# Altium schematic sheet Boost_Bar.SchDoc
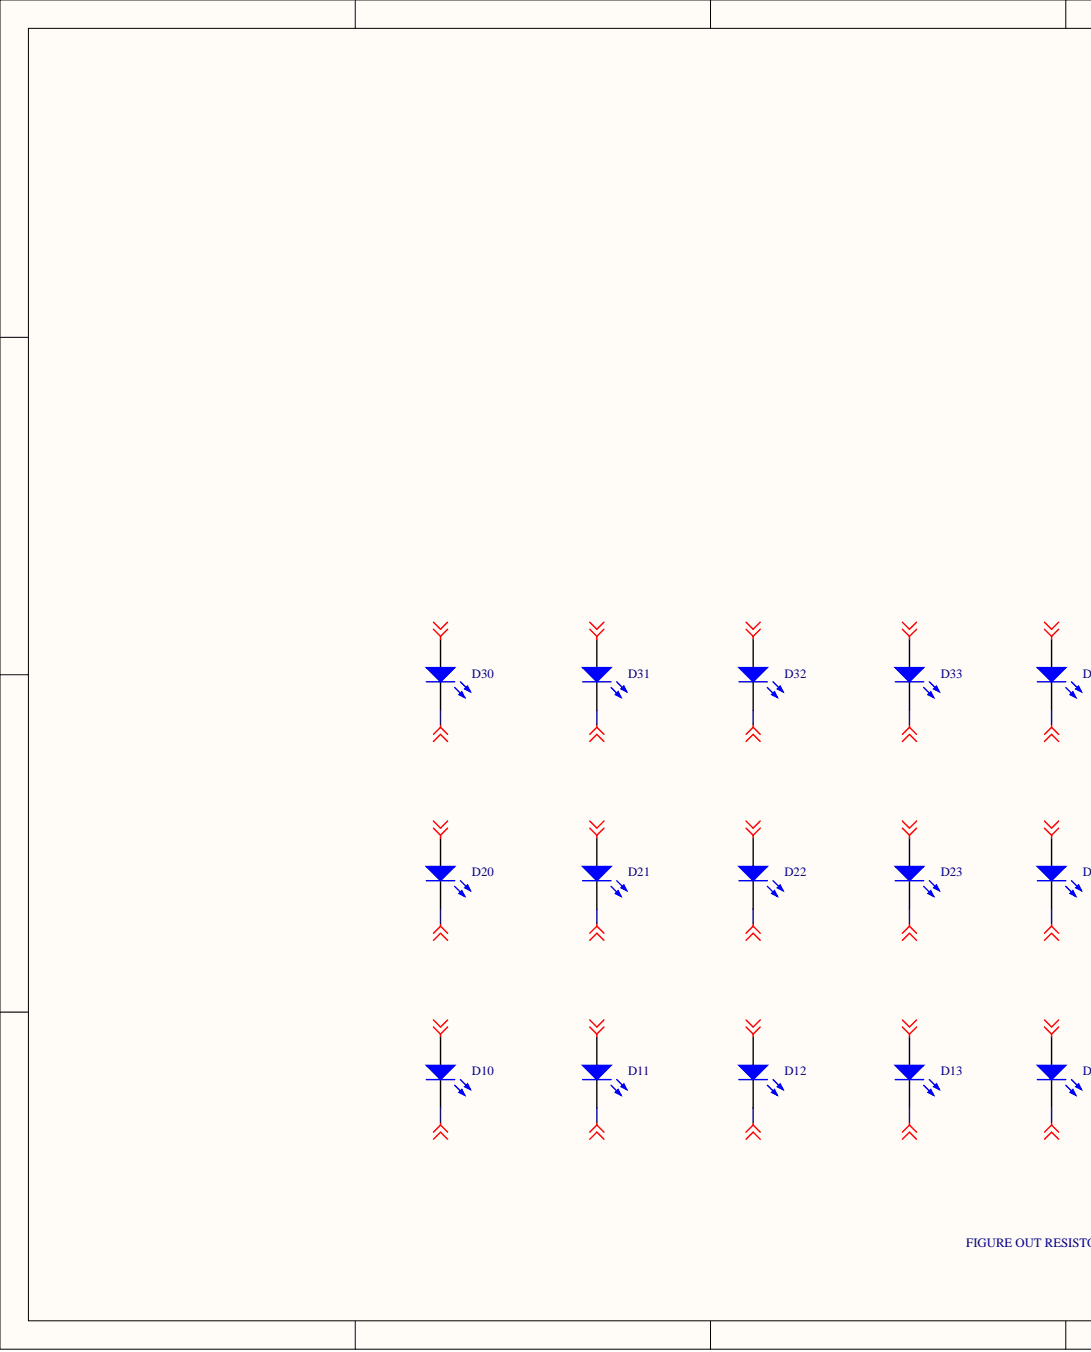
[diagram p0: Boost_Bar.SchDoc, left]
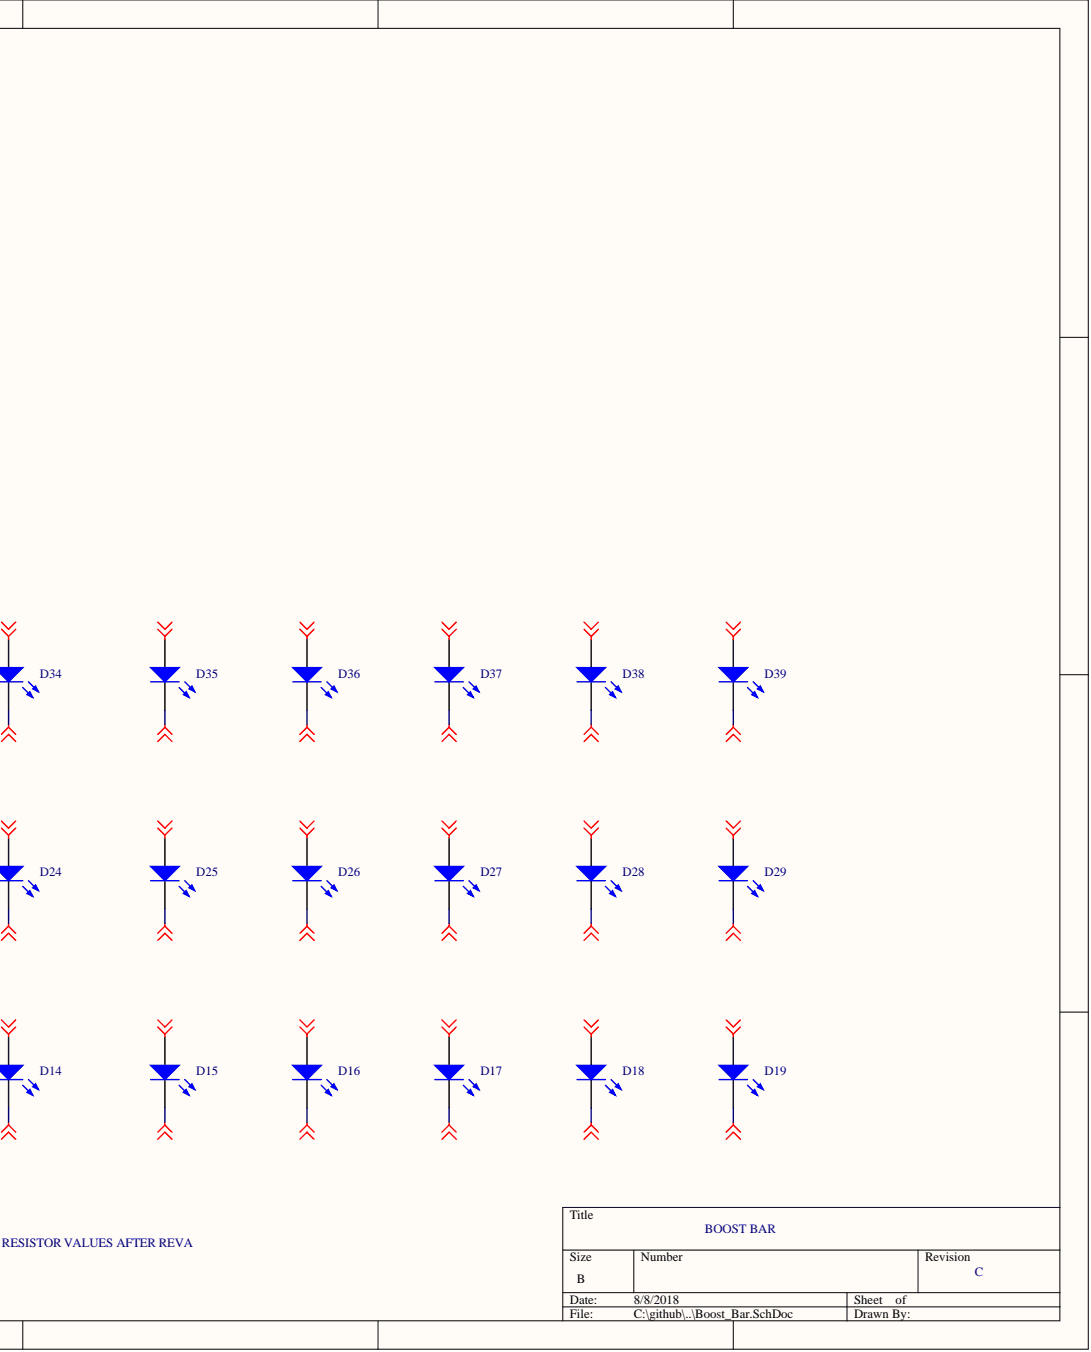
[diagram p1: Boost_Bar.SchDoc, right]

## Parts
D10  (no value)  [DIODE_0603]
D11  (no value)  [DIODE_0603]
D12  (no value)  [DIODE_0603]
D13  (no value)  [DIODE_0603]
D14  (no value)  [DIODE_0603]
D15  (no value)  [DIODE_0603]
D16  (no value)  [DIODE_0603]
D17  (no value)  [DIODE_0603]
D18  (no value)  [DIODE_0603]
D19  (no value)  [DIODE_0603]
D20  (no value)  [DIODE_0603]
D21  (no value)  [DIODE_0603]
D22  (no value)  [DIODE_0603]
D23  (no value)  [DIODE_0603]
D24  (no value)  [DIODE_0603]
D25  (no value)  [DIODE_0603]
D26  (no value)  [DIODE_0603]
D27  (no value)  [DIODE_0603]
D28  (no value)  [DIODE_0603]
D29  (no value)  [DIODE_0603]
D30  (no value)  [DIODE_0603]
D31  (no value)  [DIODE_0603]
D32  (no value)  [DIODE_0603]
D33  (no value)  [DIODE_0603]
D34  (no value)  [DIODE_0603]
D35  (no value)  [DIODE_0603]
D36  (no value)  [DIODE_0603]
D37  (no value)  [DIODE_0603]
D38  (no value)  [DIODE_0603]
D39  (no value)  [DIODE_0603]

## Nets
D0: D10.1 D11.1 D12.1 D13.1 D14.1 D15.1 D16.1 D17.1 D18.1 D19.1
D1: D20.1 D21.1 D22.1 D23.1 D24.1 D25.1 D26.1 D27.1 D28.1 D29.1
D2: D30.1 D31.1 D32.1 D33.1 D34.1 D35.1 D36.1 D37.1 D38.1 D39.1
G0/IO_VB3N2_69: D10.2 D20.2 D30.2
G1/IO_VB3N2_70: D11.2 D21.2 D31.2
G2/IO_VB3N1_78: D12.2 D22.2 D32.2
G3/IO_VB5N0_143: D13.2 D23.2 D33.2
G4/IO_VB3N1_81: D14.2 D24.2 D34.2
G5/IO_VB3N1_82: D15.2 D25.2 D35.2
G6/IO_VB3N1_83: D16.2 D26.2 D36.2
G7/IO_VB3N0_87: D17.2 D27.2 D37.2
G8/IO_VB6N3_166: D18.2 D28.2 D38.2
G9/IO_VB4N3_93: D19.2 D29.2 D39.2

## Library data of the parts
D10-D39 = LED0: Typical INFRARED GaAs LED; Component Kind: Standard; LatestRevisionDate: 08-Jul-2005; LatestRevisionNote: Mech Layer 1 removed.; Library Name: Miscellaneous Devices.IntLib; PackageDescription: LED; 2 Leads; PackageReference: LED-0; Published: 8-Jun-2000; Simulation: LED0; Publisher: Altium Limited
